# Altium schematic sheet Power.SchDoc
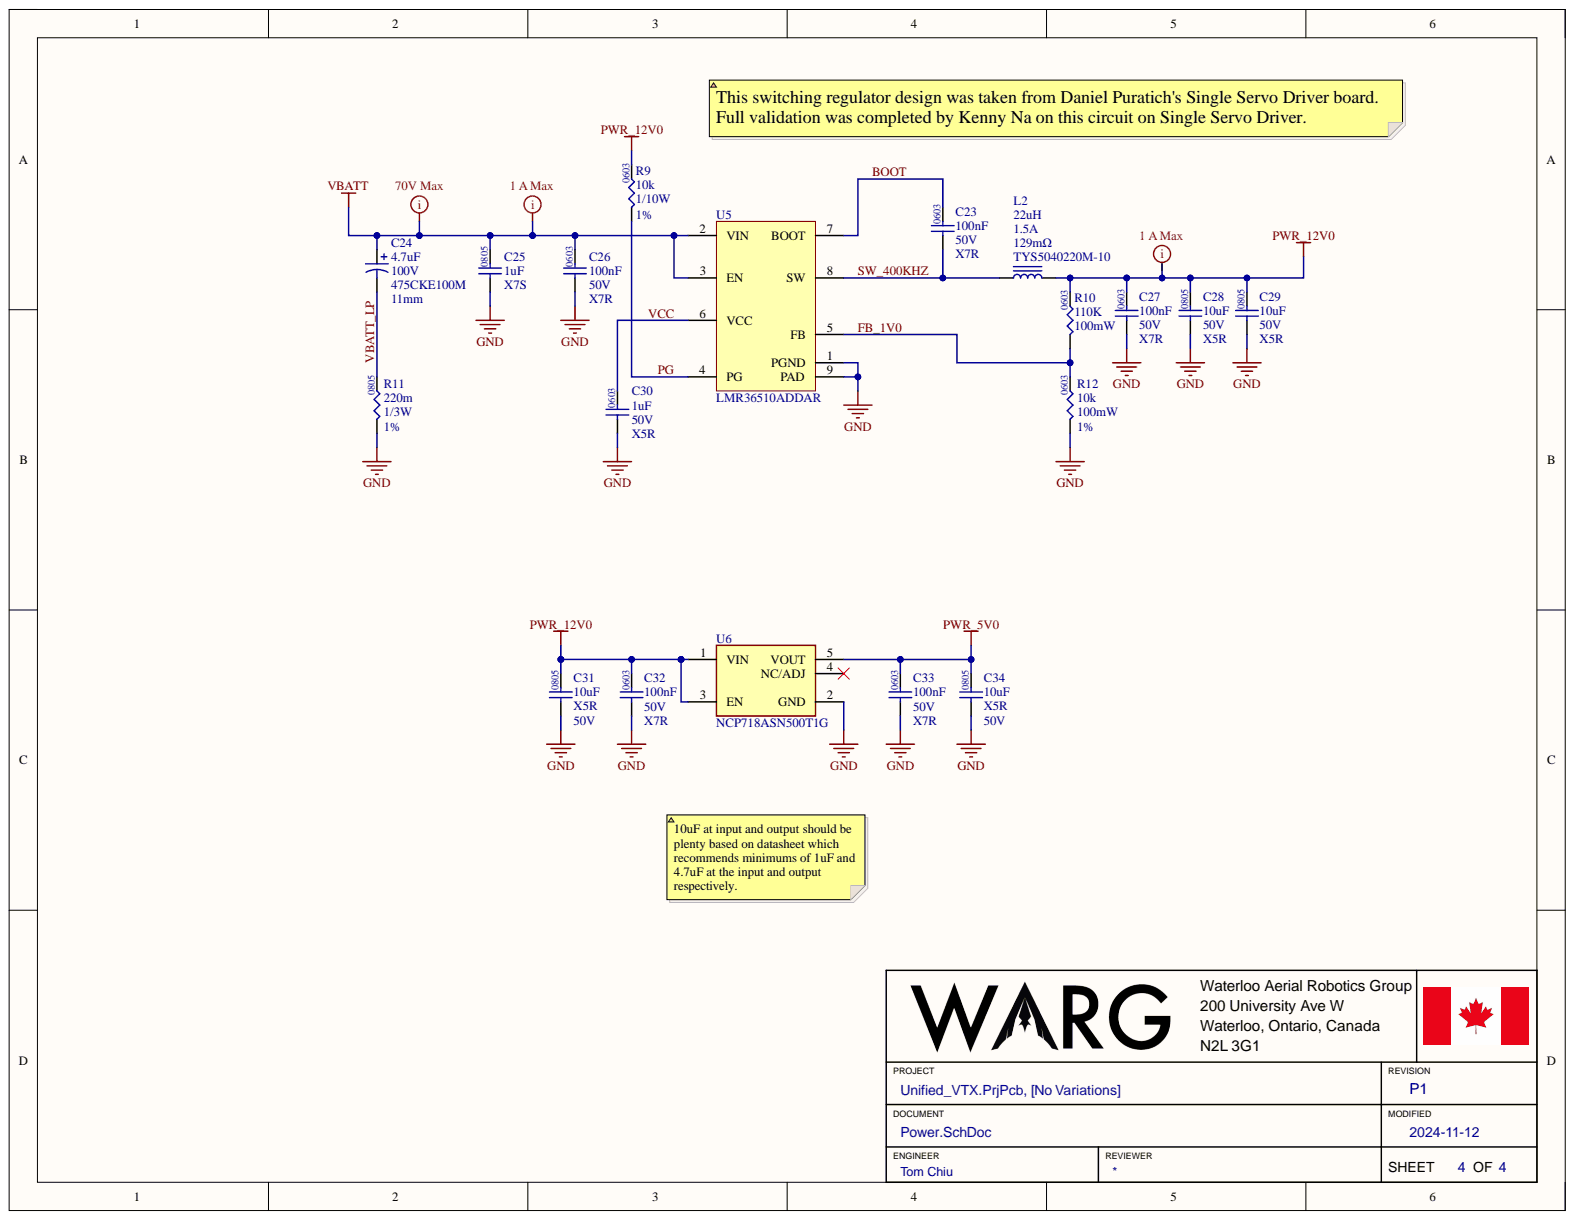
[diagram p0: Power.SchDoc]

## Parts
C23  value 100nF  Temperature Coefficient: X7R  Voltage Rating: 50V  [.CAP_0603-Footprint-1]  (hidden comment: CGA3E2X7R1H104K080AA)
C24  475CKE100M  Capacitance: 4.7uF  Height: 11mm  Voltage: 100V  [475CKE100M-Footprint-1]
C25  value 1uF  Package: 0805  Temperature Coefficient: X7S  [.CAP_0805-Footprint-1]  (hidden comment: GRM21BC72A105KE01L)
C26  value 100nF  Temperature Coefficient: X7R  Voltage Rating: 50V  [.CAP_0603-Footprint-1]  (hidden comment: CGA3E2X7R1H104K080AA)
C27  value 100nF  Temperature Coefficient: X7R  Voltage Rating: 50V  [.CAP_0603-Footprint-1]  (hidden comment: CGA3E2X7R1H104K080AA)
C28  value 10uF  Case/Package: 0805  Temperature Coefficient: X5R  Voltage Rating: 50V  [.CAP_0805-Footprint-1]  (hidden comment: GRM21BR61H106KE43L)
C29  value 10uF  Case/Package: 0805  Temperature Coefficient: X5R  Voltage Rating: 50V  [.CAP_0805-Footprint-1]  (hidden comment: GRM21BR61H106KE43L)
C30  Capacitance: 1uF  Dielectric: X5R  Package: 0603  Voltage: 50V  [.CAP_0603-Footprint-1]  (hidden comment: GRT188R61H105KE13D)
C31  value 10uF  Temperature Coefficient: X5R  Voltage Rating: 50V  [.CAP_0805-Footprint-1]  (hidden comment: GRM21BR61H106KE43L)
C32  value 100nF  Temperature Coefficient: X7R  Voltage Rating: 50V  [.CAP_0603-Footprint-1]  (hidden comment: CGA3E2X7R1H104K080AA)
C33  value 100nF  Temperature Coefficient: X7R  Voltage Rating: 50V  [.CAP_0603-Footprint-1]  (hidden comment: CGA3E2X7R1H104K080AA)
C34  value 10uF  Temperature Coefficient: X5R  Voltage Rating: 50V  [.CAP_0805-Footprint-1]  (hidden comment: GRM21BR61H106KE43L)
L2  TYS5040220M-10  DCR: 129mΩ  [TYS5040220M-10-Footprint-1]
R9  value 10k  Package: 0603  Power: 1/10W  Resistance: 10k  Tolerance: 1%  [.RES_0603-Footprint-1]  (hidden comment: RC0603FR-0710KL)
R10  value 110K  Case/Package: 0603  Power: 100mW  [.RES_0603-Footprint-1]  (hidden comment: RC0603FR-07110KL)
R11  Package: 0805  Power: 1/3W  Resistance: 220m  Tolerance: 1%  [.RES_0805-Footprint-1]  (hidden comment: RL1220S-R22-F)
R12  Package: 0603  Power: 100mW  Resistance: 10k  Tolerance: 1%  [.RES_0603-Footprint-1]  (hidden comment: AC0603FR-1310KL)
U5  LMR36510ADDAR  [LMR36510ADDAR_8SOPWR]
U6  NCP718ASN500T1G  [NCP718ASN500T1G]

## Nets
GND: C25.1 C26.1 C27.1 C28.1 C29.1 C30.1 C31.1 C32.1 C33.1 C34.1 R11.1 R12.1 U5.1(PGND) U5.9(PAD) U6.2(GND)
PWR_5V0: C33.2 C34.2 U6.5(VOUT)
PWR_12V0: C27.2 C28.2 C29.2 C31.2 C32.2 L2.2 R9.2 R10.2 U6.1(VIN) U6.3(EN)
VBATT: C24.1 C25.2 C26.2 U5.2(VIN) U5.3(EN)
BOOT: C23.2 U5.7(BOOT)
FB_1V0: R10.1 R12.2 U5.5(FB)
PG: R9.1 U5.4(PG)
SW_400KHZ: C23.1 L2.1 U5.8(SW)
VBATT_LP: C24.2 R11.2
VCC: C30.2 U5.6(VCC)

Not connected: U6 4(NC/ADJ)

## Library data of the parts
C23 C26 C27 C32 C33 = CMP-00227-5: CAP CER 0.1UF 50V X7R 0603; Component Kind: Standard; Library Name: WARG
C24 = CMP-00301-1: CAP ALUM 4.7UF 20% 100V RADIAL; Component Kind: Standard; Library Name: WARG
C25 = CMP-00124-3: CAP CER 1UF 100V X7S 0805; Tolerance: 10%; Component Kind: Standard; Voltage: 100V; Library Name: WARG
C28 C29 = CMP-001-00027-3: CAP CER 10uF 50V X5R 0805; Component Kind: Standard; Library Name: WARG
C30 = CMP-00096-4: CAP CER 1UF 50V X5R 0603; Component Kind: Standard; Library Name: WARG
C31 C34 = CMP-001-00065-3: CAP CER 10UF 50V X5R 0805; Component Kind: Standard; Library Name: WARG
L2 = CMP-00298-2: FIXED IND 22UH 1.5A 129 MOHM SMD; Component Kind: Standard; Inductance: 22uH; Saturation: 1.5A; Library Name: WARG
R9 = CMP-009-00006-5: RES 10K OHM 1% 1/10W 0603; Component Kind: Standard; Library Name: WARG
R10 = CMP-00270-1: RES 110K OHM 1% 1/10W 0603; Temperature Coefficient: 100ppm/°C; Component Kind: Standard; Tolerance: 1%; Library Name: WARG
R11 = CMP-00300-1: RES 0.22 OHM 1% 1/3W 0805; Component Kind: Standard; Library Name: WARG
R12 = CMP-009-00054-3: RES 10K OHM 1% 1/10W 0603; Component Kind: Standard; Library Name: WARG
U5 = CMP-00297-1: IC REG BUCK ADJ 1A 8SOPWR; Component Kind: Standard; Library Name: WARG
U6 = CMP-002-000012-2: IC REG LINEAR 5V 300MA TSOT23-5; Dropout Voltage1-Max: 0.49V; Maximum Output Current: 800mA; Supply Voltage Range: -0.3 - 24V; Case/Package: SOT-23-5; Component Kind: Standard; Operating Temperature Range: -40°C ~ 125°C; Output Voltage: 5V; Pins: 5; Library Name: WARG
